# Altium schematic sheet beovox-poe.SchDoc(beovox-poe)
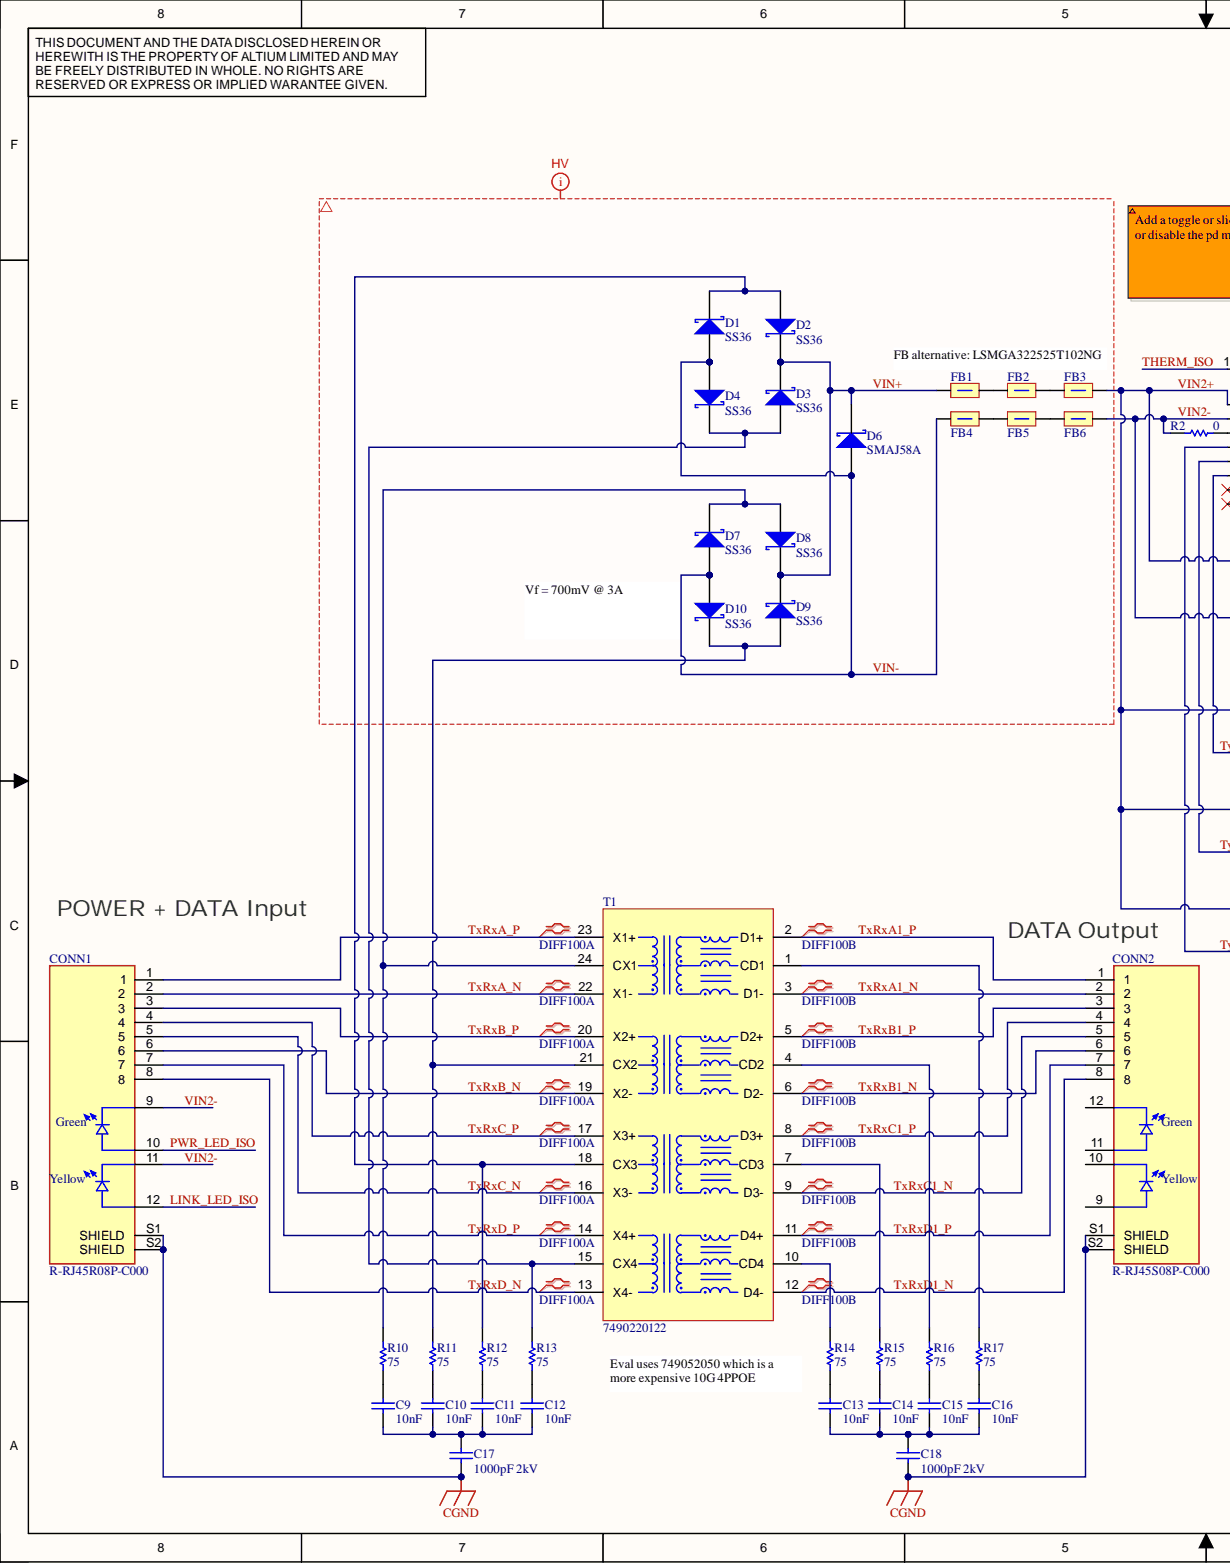
[diagram p0: beovox-poe.SchDoc, left]
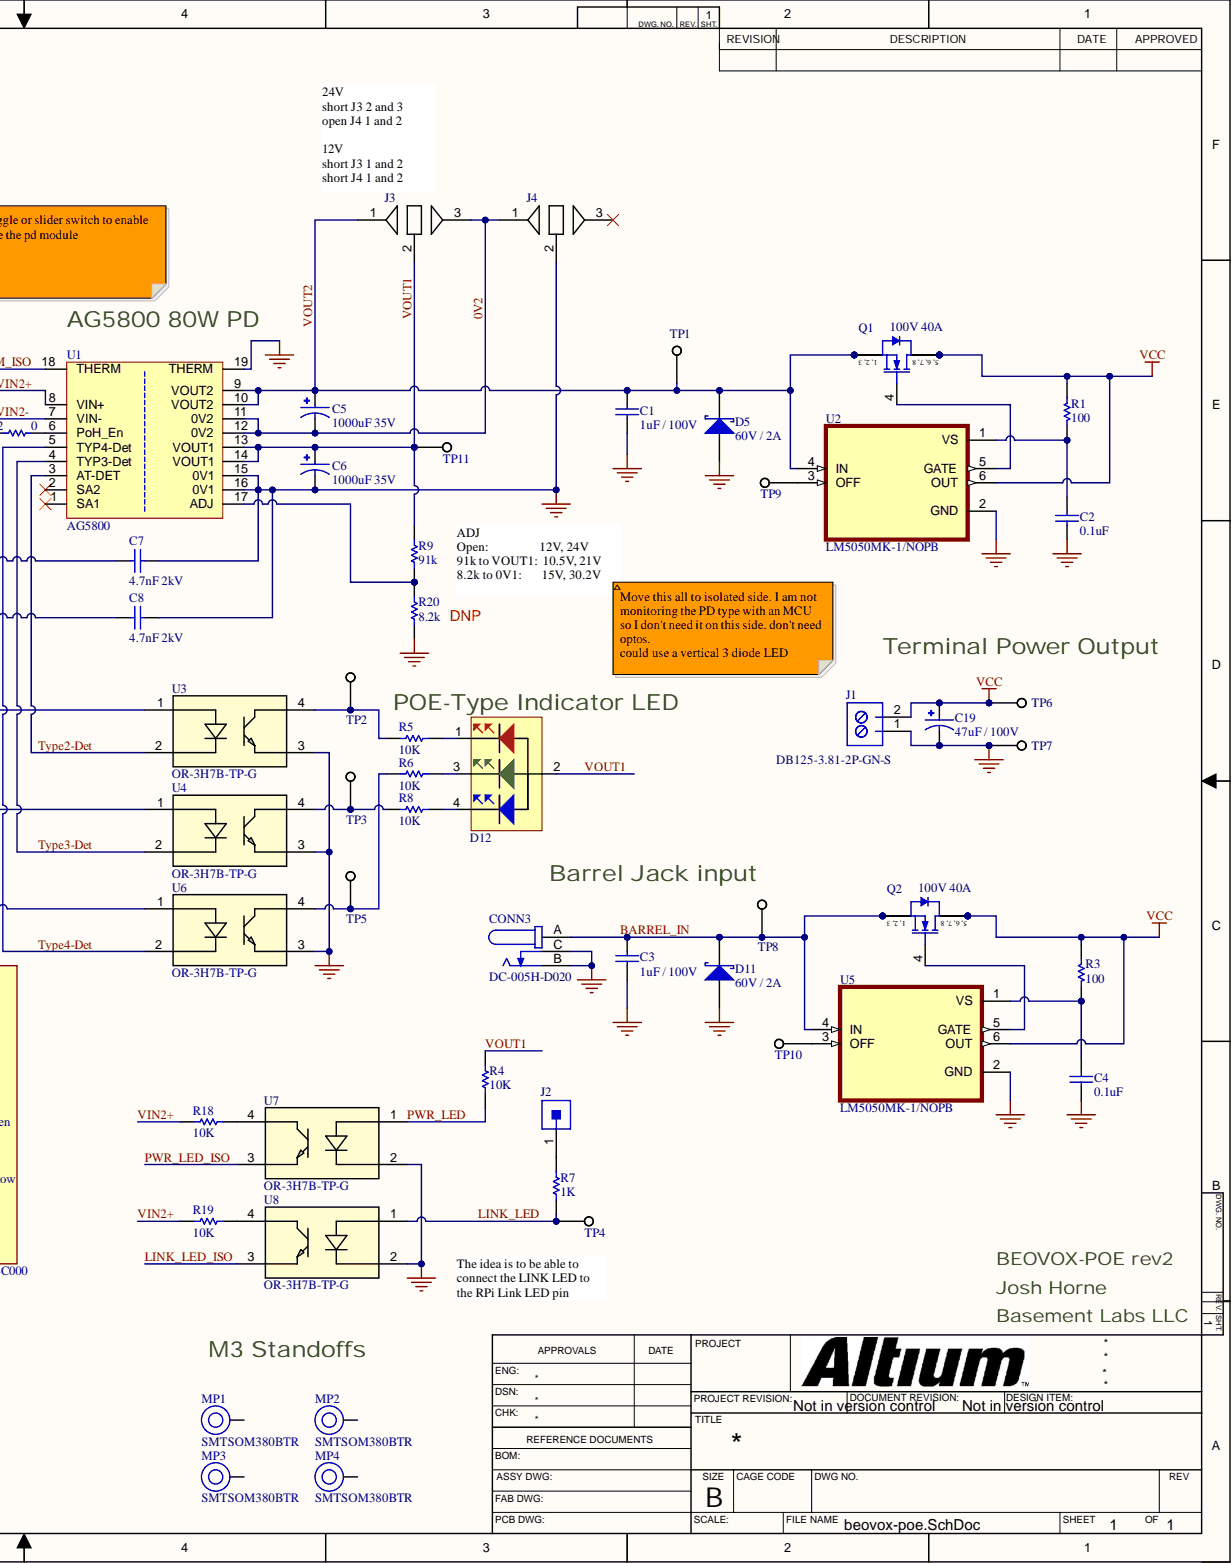
[diagram p1: beovox-poe.SchDoc, right]

## Parts
C1  1uF / 100V  Capacitance: 1uF  [CAP_1210]
C2  0.1uF  [CAP_0603_SK]
C3  1uF / 100V  Capacitance: 1uF  [CAP_1210]
C4  0.1uF  [CAP_0603_SK]
C5  1000uF 35V  value 1000uF  [CAP_TH_5_10X20]
C6  1000uF 35V  value 1000uF  [CAP_TH_5_10X20]
C7  4.7nF 2kV  [CAP_1206_SK]
C8  4.7nF 2kV  [CAP_1206_SK]
C9  10nF  [CAP_0603_SK]
C10  10nF  [CAP_0603_SK]
C11  10nF  [CAP_0603_SK]
C12  10nF  [CAP_0603_SK]
C13  10nF  [CAP_0603_SK]
C14  10nF  [CAP_0603_SK]
C15  10nF  [CAP_0603_SK]
C16  10nF  [CAP_0603_SK]
C17  1000pF 2kV  [CAP_1206_SK]
C18  1000pF 2kV  [CAP_1206_SK]
C19  47uF / 100V  [CAP_TH_3.5_8_12]
CONN1  R-RJ45R08P-C000  [R-RJ45R08P-C000]
CONN2  R-RJ45S08P-C000  [CONN_RJ45_R-RJ45S08P-C000]
CONN3  DC-005H-D020  [TENSILITY_54-00166]
D1  SS36  [DIOD-SMA-2_V]
D2  SS36  [DIOD-SMA-2_V]
D3  SS36  [DIOD-SMA-2_V]
D4  SS36  [DIOD-SMA-2_V]
D5  60V / 2A  Max Repetitive Reverse Voltage (Vrrm): 60V  [DIOD-B360A_V]
D6  SMAJ58A  [DIOD-SMA-2_V]
D7  SS36  [DIOD-SMA-2_V]
D8  SS36  [DIOD-SMA-2_V]
D9  SS36  [DIOD-SMA-2_V]
D10  SS36  [DIOD-SMA-2_V]
D11  60V / 2A  Average Rectified Current: 2A  Max Repetitive Reverse Voltage (Vrrm): 60V  [DIOD-B360A_V]
D12  FM-3510RGBA-SG  [LED_FM-3510RGBA-SG]  (value not drawn)
FB1  MPZ2012S601AT000  [FB0805]  (value not drawn)
FB2  MPZ2012S601AT000  [FB0805]  (value not drawn)
FB3  MPZ2012S601AT000  [FB0805]  (value not drawn)
FB4  MPZ2012S601AT000  [FB0805]  (value not drawn)
FB5  MPZ2012S601AT000  [FB0805]  (value not drawn)
FB6  MPZ2012S601AT000  [FB0805]  (value not drawn)
J1  DB125-3.81-2P-GN-S  [TERM_2x1_3.81]
J2  PZ254V-11-01P  [61300111121]  (value not drawn)
J3  JUMPER  [SOLDER_JUMPER_3 large]  (value not drawn)
J4  JUMPER  [SOLDER_JUMPER_3 large]  (value not drawn)
MP1  SMTSOM380BTR  [SMTSOM380]
MP2  SMTSOM380BTR  [SMTSOM380]
MP3  SMTSOM380BTR  [SMTSOM380]
MP4  SMTSOM380BTR  [SMTSOM380]
Q1  100V 40A  Continuous Drain Current (ID): 40A  Drain to Source Voltage (Vdss): 100V  [TRANS_8PowerTDFN]
Q2  100V 40A  Continuous Drain Current (ID): 40A  Drain to Source Voltage (Vdss): 100V  [TRANS_8PowerTDFN]
R1  100  [RES_0603]
R2  0  [RES_0805]
R3  100  [RES_0603]
R4  10K  [RES_0603]
R5  10K  [RES_0603]
R6  10K  [RES_0603]
R7  1K  [RES_0603]
R8  10K  [RES_0603]
R9  91k  [RES_0603]
R10  75  [RES_0603]
R11  75  [RES_0603]
R12  75  [RES_0603]
R13  75  [RES_0603]
R14  75  [RES_0603]
R15  75  [RES_0603]
R16  75  [RES_0603]
R17  75  [RES_0603]
R18  10K  [RES_0603]
R19  10K  [RES_0603]
R20  8.2k  [RES_0603]
T1  7490220122  [XFMR_7490220122]
TP1  TP  [Test_Point]  (no BOM)
TP2  TP  [Test_Point]  (no BOM)
TP3  TP  [Test_Point]  (no BOM)
TP4  TP  [Test_Point]  (no BOM)
TP5  TP  [Test_Point]  (no BOM)
TP6  TP  [Test_Point]  (no BOM)
TP7  TP  [Test_Point]  (no BOM)
TP8  TP  [Test_Point]  (no BOM)
TP9  TP  [Test_Point]  (no BOM)
TP10  TP  [Test_Point]  (no BOM)
TP11  TP  [Test_Point]  (no BOM)
U1  AG5800  [AG5800]
U2  LM5050MK-1/NOPB  [SOT95P280X110-6N]
U3  OR-3H7B-TP-G  [SOIC_(B)(TA)-VG_EVS]
U4  OR-3H7B-TP-G  [SOIC_(B)(TA)-VG_EVS]
U5  LM5050MK-1/NOPB  [SOT95P280X110-6N]
U6  OR-3H7B-TP-G  [SOIC_(B)(TA)-VG_EVS]
U7  OR-3H7B-TP-G  [SOIC_(B)(TA)-VG_EVS]
U8  OR-3H7B-TP-G  [SOIC_(B)(TA)-VG_EVS]

## Nets
CGND: C17.1 C18.1 CONN1.S1(SHIELD) CONN1.S2(SHIELD) CONN2.S1(SHIELD) CONN2.S2(SHIELD)
GND: C1.1 C2.1 C3.1 C4.1 C6.N C7.2 C8.2 C19.N CONN3.B CONN3.C D5.1 D11.1 J1.1 J4.2 R20.1 TP7.1 U1.15(0V1) U1.16(0V1) U1.19(THERM) U2.2(GND) U3.3 U4.3 U5.2(GND) U6.3 U7.2 U8.2
VCC: C19.P J1.2 Q1.5 Q1.6 Q1.7 Q1.8 Q2.5 Q2.6 Q2.7 Q2.8 R1.2 R3.2 TP6.1 U2.6(OUT) U5.6(OUT)
0V2: C5.N J3.3 J4.1 U1.11(0V2) U1.12(0V2)
BARREL_IN: C3.2 CONN3.A D11.2 Q2.1 Q2.2 Q2.3 TP8.1 U5.4(IN)
LINK_LED: R7.1 TP4.1 U8.1
LINK_LED_ISO: CONN1.12 U8.3
PWR_LED: R4.1 U7.1
PWR_LED_ISO: CONN1.10 U7.3
THERM_ISO: U1.18(THERM)
TxRxA1_N: CONN2.2 T1.3(D1-)
TxRxA1_P: CONN2.1 T1.2(D1+)
TxRxA_N: CONN1.2 T1.22(X1-)
TxRxA_P: CONN1.1 T1.23(X1+)
TxRxB1_N: CONN2.6 T1.6(D2-)
TxRxB1_P: CONN2.3 T1.5(D2+)
TxRxB_N: CONN1.6 T1.19(X2-)
TxRxB_P: CONN1.3 T1.20(X2+)
TxRxC1_N: CONN2.5 T1.9(D3-)
TxRxC1_P: CONN2.4 T1.8(D3+)
TxRxC_N: CONN1.5 T1.16(X3-)
TxRxC_P: CONN1.4 T1.17(X3+)
TxRxD1_N: CONN2.8 T1.12(D4-)
TxRxD1_P: CONN2.7 T1.11(D4+)
TxRxD_N: CONN1.8 T1.13(X4-)
TxRxD_P: CONN1.7 T1.14(X4+)
Type2-Det: U1.3(AT-DET) U3.2
Type3-Det: U1.4(TYP3-Det) U4.2
Type4-Det: U1.5(TYP4-Det) U6.2
VIN2+: C7.1 FB3.2 R18.2 R19.2 U1.8(VIN+) U3.1 U4.1 U6.1
VIN2-: C8.1 CONN1.9 CONN1.11 FB6.2 R2.1 U1.7(VIN-)
VIN+: D2.2 D3.2 D6.2 D8.2 D9.2 FB1.1
VIN-: D1.1 D4.1 D6.1 D7.1 D10.1 FB4.1
VOUT1: C6.P D12.2 J3.2 R4.2 R9.1 TP11.1 U1.13(VOUT1) U1.14(VOUT1)
VOUT2: C1.2 C5.P D5.2 J3.1 Q1.1 Q1.2 Q1.3 TP1.1 U1.9(VOUT2) U1.10(VOUT2) U2.4(IN)
NetC2_2: C2.2 R1.1 U2.1(VS)
NetC4_2: C4.2 R3.1 U5.1(VS)
NetC9_1: C9.1 C10.1 C11.1 C12.1 C17.2
NetC9_2: C9.2 R10.1
NetC10_2: C10.2 R11.1
NetC11_2: C11.2 R12.1
NetC12_2: C12.2 R13.1
NetC13_1: C13.1 C14.1 C15.1 C16.1 C18.2
NetC13_2: C13.2 R14.1
NetC14_2: C14.2 R15.1
NetC15_2: C15.2 R16.1
NetC16_2: C16.2 R17.1
NetD1_2: D1.2 D2.1 R12.2 T1.18(CX3)
NetD3_1: D3.1 D4.2 R13.2 T1.15(CX4)
NetD7_2: D7.2 D8.1 R10.2 T1.24(CX1)
NetD9_1: D9.1 D10.2 R11.2 T1.21(CX2)
NetD12_1: D12.1 R5.1
NetD12_3: D12.3 R6.1
NetD12_4: D12.4 R8.1
NetFB1_2: FB1.2 FB2.1
NetFB2_2: FB2.2 FB3.1
NetFB4_2: FB4.2 FB5.1
NetFB5_2: FB5.2 FB6.1
NetJ2_1: J2.1 R7.2
NetQ1_4: Q1.4 U2.5(GATE)
NetQ2_4: Q2.4 U5.5(GATE)
NetR2_2: R2.2 U1.6(PoH_En)
NetR5_2: R5.2 TP2.1 U3.4
NetR6_2: R6.2 TP5.1 U6.4
NetR8_2: R8.2 TP3.1 U4.4
NetR9_2: R9.2 R20.2 U1.17(ADJ)
NetR14_2: R14.2 T1.10(CD4)
NetR15_2: R15.2 T1.7(CD3)
NetR16_2: R16.2 T1.4(CD2)
NetR17_2: R17.2 T1.1(CD1)
NetR18_1: R18.1 U7.4
NetR19_1: R19.1 U8.4
NetTP9_1: TP9.1 U2.3(OFF)
NetTP10_1: TP10.1 U5.3(OFF)

Not connected: CONN2 9 10 11 12; J4 3; MP1 1; MP2 1; MP3 1; MP4 1; U1 1(SA1) 2(SA2)
